# Altium schematic sheet Sheet1.SchDoc
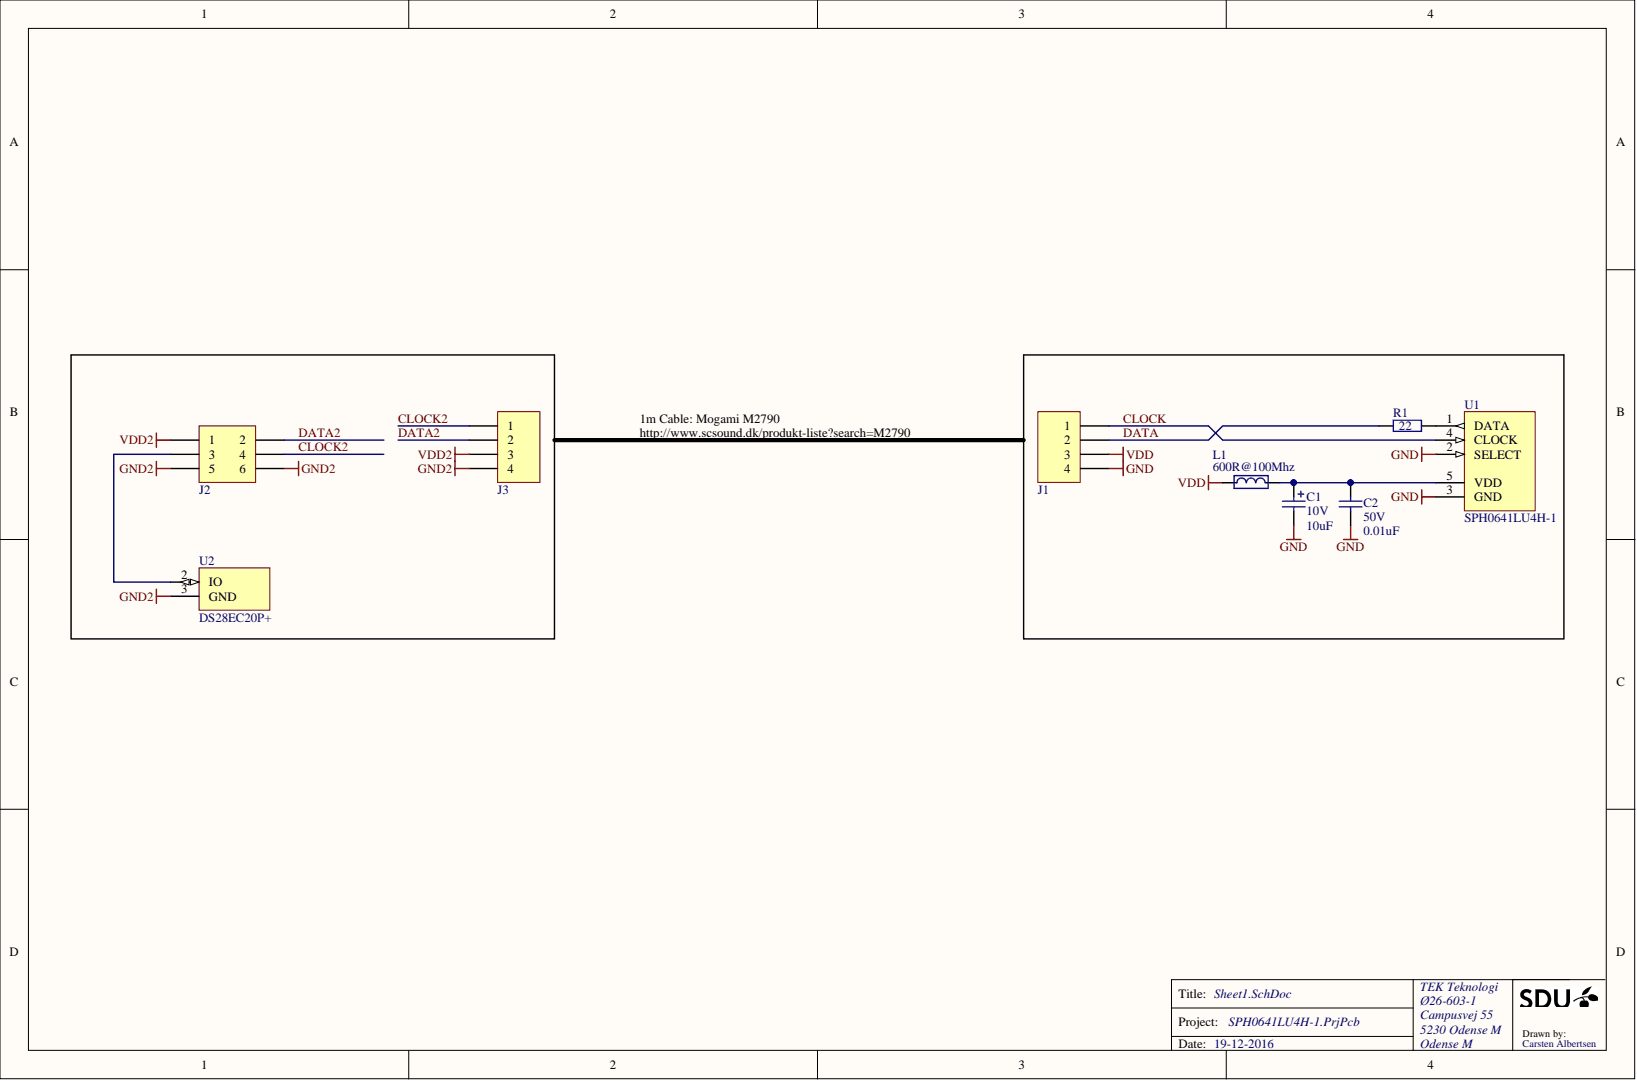
[diagram p0: Sheet1.SchDoc]

## Parts
C1  10V  value 10uF  [TANTAL SIZE A]
C2  50V  value 0.01uF  [0603C]
J1  1x4 Header  [3+Shield mini cable connections]  (value not drawn)
J2  2x3 Header  [HDR2X3 FLAT SIDE]  (value not drawn)
J3  1x4 Header  [3+Shield mini cable connections]  (value not drawn)
L1  600R@100Mhz  [0603L]
R1  value 22  [0603R]  (hidden comment: 1%)
U1  SPH0641LU4H-1  [SPH0641LU4H-1]
U2  DS28EC20P+  [TSOC6]

## Nets
GND: C1.2 C2.1 J1.4 U1.2(SELECT) U1.3(GND)
GND2: J2.5 J2.6 J3.4 U2.3
VDD: J1.3 L1.1
VDD2: J2.1 J3.3
CLOCK: J1.1 U1.4(CLOCK)
CLOCK2: J2.4 J3.1
DATA: J1.2 R1.1
DATA2: J2.2 J3.2
NetC1_1: C1.1 C2.2 L1.2 U1.5(VDD)
NetJ2_3: J2.3 U2.2
NetR1_2: R1.2 U1.1(DATA)

## Library data of the parts
C1 = Cap_CaseA_10uF: Tantal, SMD, CaseA; Component Kind: Standard; Simulation: CAP; Supplier 1: RS-Components; Supplier 2: Farnell; Supplier 3: Digi-Key; Supplier 4: Mouser; Supplier Part Number 1: 684-5086; Library Name: Ofte_Benyttede.SCHLIB
C2 = Cap_0603_.01uF: Capacitor, SMD, 0603, NPO; Component Kind: Standard; Simulation: CAP; Supplier 1: RS-Components; Supplier 2: Farnell; Supplier 3: Digi-Key; Supplier 4: Mouser; Library Name: Ofte_Benyttede.SCHLIB
J1 J3 = 1x4 Header: Header Male 1x4; Component Kind: Standard; Supplier 1: RS-Components; Supplier 2: Farnell; Supplier 3: Digi-Key; Supplier 4: Mouser; Library Name: Connector_Div_egne.SchLib
J2 = 2x3 Header: Header Male 2x3; Component Kind: Standard; Supplier 1: RS-Components; Supplier 2: Farnell; Supplier 3: Digi-Key; Supplier 4: Mouser; Library Name: Connector_Div_egne.SchLib
L1 = Ferrite_0603: Ferrite bead 1A, 200mOhm, 600R@100Mhz; Component Kind: Standard; ComponentLink1Description: http://katalog.we-online.de/kataloge/eisos/media/pdf/742792651.pdf; ComponentLink1URL: http://katalog.we-online.de/kataloge/eisos/media/pdf/742792651.pdf; Supplier 1: RS-Components; Supplier 2: Farnell; Supplier 3: Digi-Key; Supplier 4: Mouser; Supplier Part Number 1: 669-4046; Supplier Part Number 2: 1635706; Value: 600R@100Mhz; Library Name: Ofte_Benyttede.SCHLIB
R1 = Res_0603: Resistor, SMD, 0603, 0.063W; Component Kind: Standard; Simulation: RESISTOR; Supplier 1: RS-Components; Supplier 2: Farnell; Supplier 3: Digi-Key; Supplier 4: Mouser; Library Name: Ofte_Benyttede.SCHLIB
U1 = SPH0641LU4H-1: Digital Zero-Height SiSonicTM Microphone  With Multi-Mode And Ultrasonic Support; Component Kind: Standard; ComponentLink1Description: http://www.knowles.com/eng/content/download/5990/105795/version/1/file/SPH0641LU4H-1.pdf; ComponentLink1URL: http://www.knowles.com/eng/content/download/5990/105795/version/1/file/SPH0641LU4H-1.pdf; Supplier 1: RS-Components; Supplier 2: Farnell; Supplier 3: Digi-Key; Supplier 4: Mouser; Supplier Part Number 3: 423-1402-1-ND; Library Name: DivLib.SCHLIB
U2 = DS28EC20P+: 20Kb 1-Wire EEPROM; Component Kind: Standard; ComponentLink1Description: http://datasheets.maximintegrated.com/en/ds/DS28EC20.pdf; ComponentLink1URL: http://datasheets.maximintegrated.com/en/ds/DS28EC20.pdf; Supplier 1: RS-Components; Supplier 2: Farnell; Supplier 3: Digi-Key; Supplier 4: Mouser; Supplier Part Number 3: DS28EC20P+-ND; Library Name: Maxim_egne.SchLib
